# Altium schematic sheet TEST.SchDoc
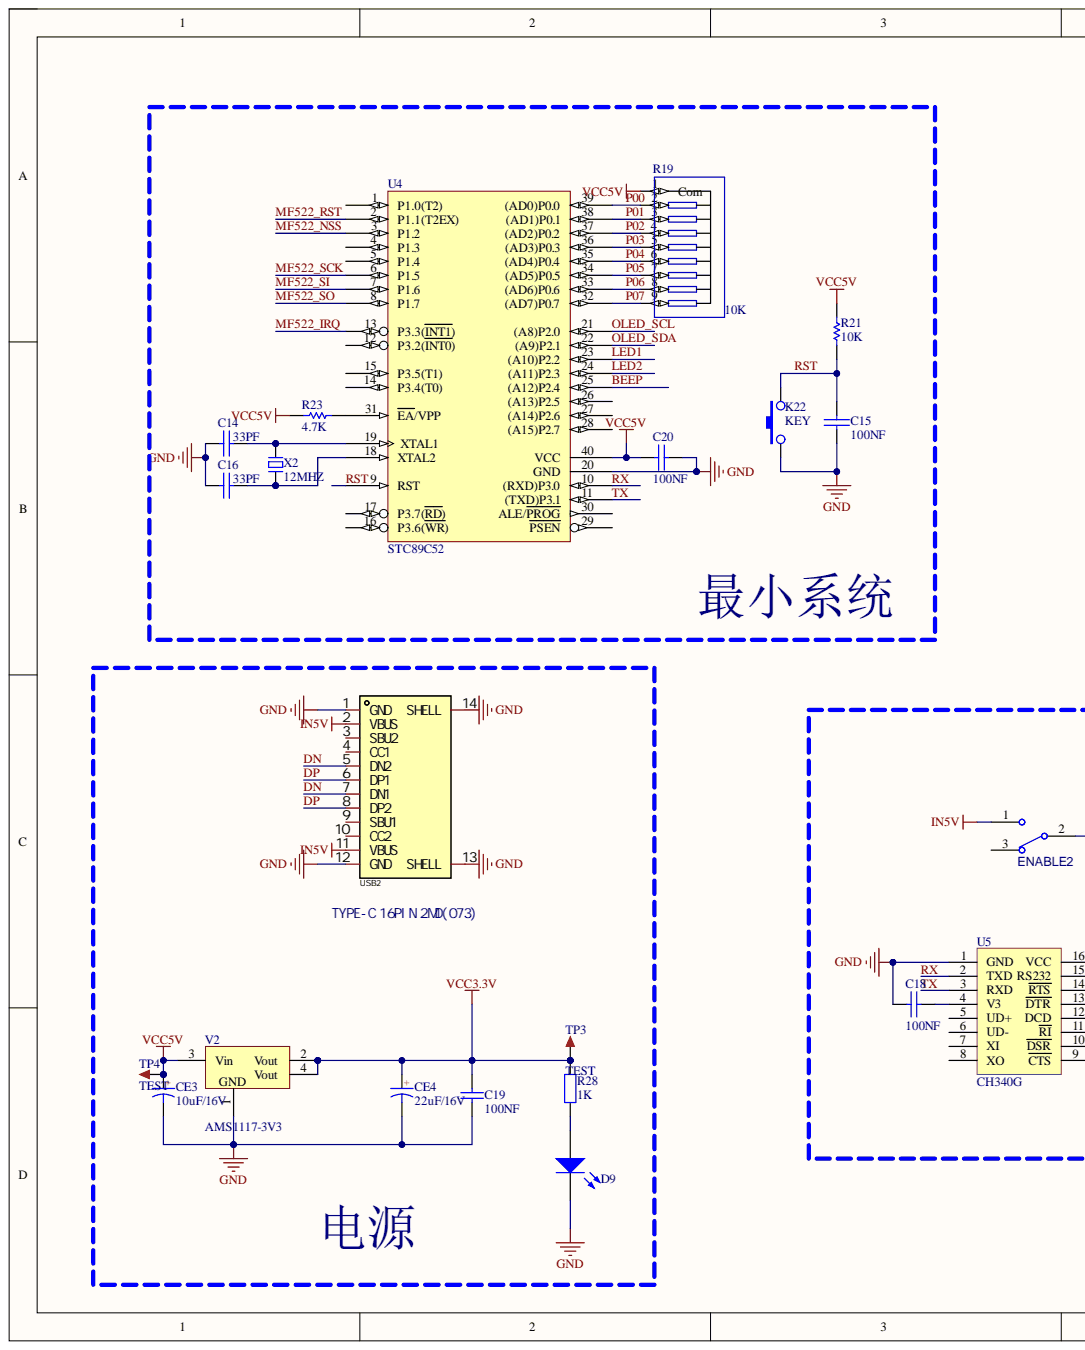
[diagram p0: TEST.SchDoc, left]
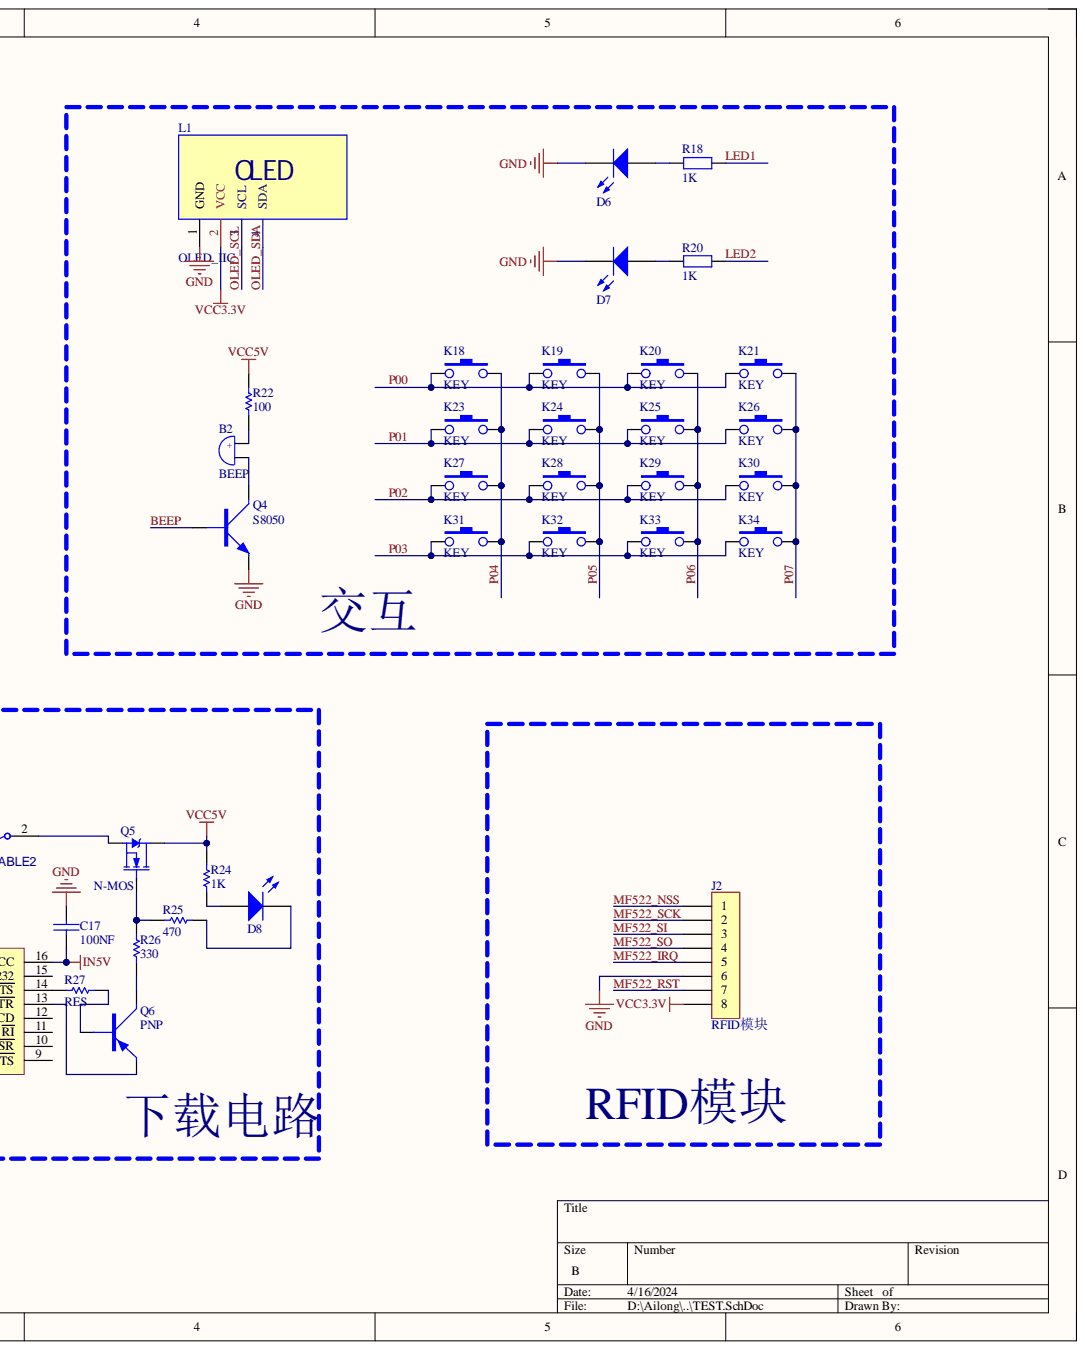
[diagram p1: TEST.SchDoc, right]

## Parts
B2  BEEP  [BEEP 5x9x5.5]
C14  33PF  [0201C]
C15  100NF  [0201C]
C16  33PF  [0201C]
C17  100NF  [0201C]
C18  100NF  [0201C]
C19  100NF  [0603C]
C20  100NF  [0201C]
CE3  10uF/16V  [DIP-EC2.0X5X11]
CE4  22uF/16V  [DIP-EC2.0X5X11]
D6  LED  [LED 0603W]  (value not drawn)
D7  LED  [LED 0603W]  (value not drawn)
D8  LED  [LED 0603W]  (value not drawn)
D9  LED  [LED 0603W]  (value not drawn)
ENABLE2  SW-SPDT  [SS12D10]  (value not drawn)
J2  RFID模块  [Pin HDR1X8/2.54mm-S]
K18  KEY  [DIP-3X6X4.3]
K19  KEY  [DIP-3X6X4.3]
K20  KEY  [DIP-3X6X4.3]
K21  KEY  [DIP-3X6X4.3]
K22  KEY  [DIP-3X6X4.3]
K23  KEY  [DIP-3X6X4.3]
K24  KEY  [DIP-3X6X4.3]
K25  KEY  [DIP-3X6X4.3]
K26  KEY  [DIP-3X6X4.3]
K27  KEY  [DIP-3X6X4.3]
K28  KEY  [DIP-3X6X4.3]
K29  KEY  [DIP-3X6X4.3]
K30  KEY  [DIP-3X6X4.3]
K31  KEY  [DIP-3X6X4.3]
K32  KEY  [DIP-3X6X4.3]
K33  KEY  [DIP-3X6X4.3]
K34  KEY  [DIP-3X6X4.3]
L1  OLED_IIC
Q4  S8050  [SOT-23]
Q5  N-MOS  [TO220A]
Q6  PNP  [SOT-23]
R18  1K  [0603R]
R19  10K  [A09R]
R20  1K  [0603R]
R21  10K  [0201R]
R22  100  [0201R]
R23  4.7K  [0201R]
R24  1K  [0201R]
R25  470  [0201R]
R26  330  [0201R]
R27  RES  [0201R]
R28  1K  [0603R]
TP3  TEST  [TEST 1.6]
TP4  TEST  [TEST 1.6]
U4  STC89C52  [DIP40]
U5  CH340G
USB2  TYPE-C 16PIN 2MD(073)  [USB-C-SMD_TYPE-C-6PIN-2MD-073]
V2  AMS1117-3V3  [SOT223]
X2  12MHZ  [OSC HC-49S]

## Nets
GND: C14.1 C15.1 C16.1 C17.2 C18.2 C19.2 C20.1 CE3.2 CE4.2 D6.2 D7.2 D9.2 J2.6 K22.1 L1.1(GND) Q4.E U4.20(GND) U5.1(GND) USB2.1(GND) USB2.12(GND) USB2.13(SHELL) USB2.14(SHELL) V2.1
IN5V: C17.1 ENABLE2.1 U5.16(VCC) USB2.2(VBUS) USB2.11(VBUS)
VCC3.3V: C19.1 CE4.1 J2.8 L1.2(VCC) R28.2 TP3.1 V2.2(Vout) V2.4(Vout)
VCC5V: C20.2 CE3.1 Q5.D R19.1(Com) R21.2 R22.2 R23.1 R24.1 TP4.1 U4.40(VCC) V2.3(Vin)
BEEP: Q4.B U4.25((A12)P2.4)
DN: USB2.5(DN2) USB2.7(DN1)
DP: USB2.6(DP1) USB2.8(DP2)
LED1: R18.2 U4.23((A10)P2.2)
LED2: R20.2 U4.24((A11)P2.3)
MF522_IRQ: J2.5 U4.13(P3.3(INT1))
MF522_NSS: J2.1 U4.3(P1.2)
MF522_RST: J2.7 U4.2(P1.1(T2EX))
MF522_SCK: J2.2 U4.6(P1.5)
MF522_SI: J2.3 U4.7(P1.6)
MF522_SO: J2.4 U4.8(P1.7)
OLED_SCL: L1.3(SCL) U4.21((A8)P2.0)
OLED_SDA: L1.4(SDA) U4.22((A9)P2.1)
P00: K18.1 K19.1 K20.1 K21.1 R19.2 U4.39((AD0)P0.0)
P01: K23.1 K24.1 K25.1 K26.1 R19.3 U4.38((AD1)P0.1)
P02: K27.1 K28.1 K29.1 K30.1 R19.4 U4.37((AD2)P0.2)
P03: K31.1 K32.1 K33.1 K34.1 R19.5 U4.36((AD3)P0.3)
P04: K18.3 K23.3 K27.3 K31.3 R19.6 U4.35((AD4)P0.4)
P05: K19.3 K24.3 K28.3 K32.3 R19.7 U4.34((AD5)P0.5)
P06: K20.3 K25.3 K29.3 K33.3 R19.8 U4.33((AD6)P0.6)
P07: K21.3 K26.3 K30.3 K34.3 R19.9 U4.32((AD7)P0.7)
RST: C15.2 K22.3 R21.1 U4.9(RST)
RX: U4.10((RXD)P3.0) U5.2(TXD)
TX: U4.11((TXD)P3.1) U5.3(RXD)
NetB2_1: B2.1 R22.1
NetB2_2: B2.2 Q4.C
NetC14_2: C14.2 U4.19(XTAL1) X2.2
NetC16_2: C16.2 U4.18(XTAL2) X2.1
NetC18_1: C18.1 U5.4(V3)
NetD6_1: D6.1 R18.1
NetD7_1: D7.1 R20.1
NetD8_1: D8.1 R24.2
NetD8_2: D8.2 R25.2
NetD9_1: D9.1 R28.1
NetENABLE2_2: ENABLE2.2 Q5.S
NetQ5_G: Q5.G R25.1 R26.2
NetQ6_B: Q6.B R27.2
NetQ6_C: Q6.C R26.1
NetQ6_E: Q6.E U5.13(DTR)
NetR23_2: R23.2 U4.31(EA/VPP)
NetR27_1: R27.1 U5.14(RTS)

Not connected: ENABLE2 3; U4 1(P1.0(T2)) 4(P1.3) 5(P1.4) 12(P3.2(INT0)) 14(P3.4(T0)) 15(P3.5(T1)) 16(P3.6(WR)) 17(P3.7(RD)) 26((A13)P2.5) 27((A14)P2.6) 28((A15)P2.7) 29(PSEN) 30(ALE/PROG); U5 5(UD+) 6(UD-) 7(XI) 8(XO) 9(CTS) 10(DSR) 11(RI) 12(DCD) 15(RS232); USB2 3(SBU2) 4(CC1) 9(SBU1) 10(CC2)

## Library data of the parts
B2 = BEEP: 蜂鸣器; Component Kind: Standard; Library Name: 蜂鸣器.SchLib
C14-C18 C20 = CAP: 无极性电容; Component Kind: Standard; Library Name: 电容.SchLib
C19 = C: 贴片电容; BOM名称: 无极性贴片电容; 联系卖家，免费查找封装: 唯一出售店铺网址https://shop463601444.taobao.com; Component Kind: Standard; Library Name: 0.1 - 电阻-电容-电感-磁珠-电位器.SchLib
CE3 CE4 = CE: 直插电解电容; 封装库来自淘宝卖家ID：黄晶宝石: 其他店铺购入均为盗版假冒封装库，请立即申请退款; 联系卖家，免费查找封装: 唯一出售店铺网址https://shop463601444.taobao.com; BOM名称: 直插电解电容; Component Kind: Standard; Library Name: 0.1 - 电阻-电容-电感-磁珠-电位器.SchLib
D6-D9 = LED: 发光二极管; 封装库来自淘宝卖家 ID：黄晶宝石: 其他店铺购入均为假冒，请立即申请退款，本店奖励现金5~20元; Component Kind: Standard; Library Name: 0.3 - LED发光二极管.SchLib
ENABLE2 = SW-SPDT: 单刀双掷开关 3脚船型、钮子、拨动开关 SPDT Subminiature Toggle Switch; Component Kind: Standard; Price(RMB): 0.000; Published: 24-Mar-1999; Library Name: 灵动SPIN360C 无刷驱动V1.6 集成封装库.IntLib; Publisher: Altium Limited
J2 = Pin HDR1X8: Component Kind: Standard; Library Name: 排针.SchLib
Q4 = NPN: NPN三极管; Component Kind: Standard; Library Name: 三极管.SchLib
Q5 = N-MOS: N沟道场效应管; Component Kind: Standard; Library Name: MOS管.SchLib
Q6 = PNP: PNP三极管; Component Kind: Standard; Library Name: 三极管.SchLib
R18 R20 R28 = R: 贴片电阻; 封装库来自淘宝卖家ID：黄晶宝石: 其他店铺购入均为盗版假冒封装库，请立即申请退款; 联系卖家，免费查找封装: 唯一出售店铺网址https://shop463601444.taobao.com; BOM名称: 贴片电阻; Component Kind: Standard; Library Name: 0.1 - 电阻-电容-电感-磁珠-电位器.SchLib
R19 = RES_PACK9: Component Kind: Standard; Library Name: 电阻.SchLib
R21-R26 = RES: Component Kind: Standard; Library Name: 电阻.SchLib
TP3 TP4 = TEST: 测试点; 联系卖家，免费查找封装: 唯一出售店铺网址https://shop463601444.taobao.com; BOM名称: 测试点; Component Kind: Standard; Library Name: 0.7 - 常用按键-开关-蜂鸣器-电池-测试点.SchLib
USB2 = TYPE-C 16PIN 2MD(073): Manufacturer: SHOU HAN(首韩); Add into BOM: yes; Current Rating - Power (Max): 5A; Gender: 母; Number of Contacts: 16P; Number of Ports: 1; Component Kind: Standard; Connector Type: Type-C; Convert to PCB: yes; Datasheet: https://atta.szlcsc.com/upload/public/pdf/source/20211123/39B17C16241EAC15C5E277BDA434118E.pdf; Device: TYPE-C 16PIN 2MD(073); JLCPCB Part Class: Extended Part; LCSC Part Name: Type-C 母 卧贴; Library Name: USB.SchLib; Manufacturer Part: TYPE-C 16PIN 2MD(073); Mounting Style: 卧贴; Operating Temperature Range: -25℃~+85℃; Standard: -; Supplier: LCSC; Supplier Footprint: SMD; Supplier Part: C2765186; Symbol: TYPE-C 16PIN 2MD(073)
V2 = AMS1117: 三端稳压芯片; BOM名称: 稳压芯片; 封装库来自淘宝卖家 ID：黄晶宝石: 其他店铺购入均为盗版，请立即申请退款，本店现金奖励5~20元; 联系卖家 免费查找封装: 唯一出售店铺网址https://shop463601444.taobao.com; Component Kind: Standard; Library Name: 1.1 - 电源类芯片.SchLib
X2 = XTAL-2P: 2脚无源脚晶振; Component Kind: Standard; Library Name: 晶振.SchLib
K18-K34 = KEY: Component Kind: Standard; Library Name: 轻触开关.SchLib
L1 = OLED_IIC: Component Kind: Standard; Library Name: LCD.SchLib
R27 = RES: Component Kind: Standard; Library Name: 电阻.SchLib
U4 = STC89C52: Component Kind: Standard; Library Name: STC系列芯片.SchLib
U5 = CH340G: Component Kind: Standard; Library Name: 通讯系列IC.SchLib
